# Altium schematic sheet ShematicProva.SchDoc(ShematicProva)
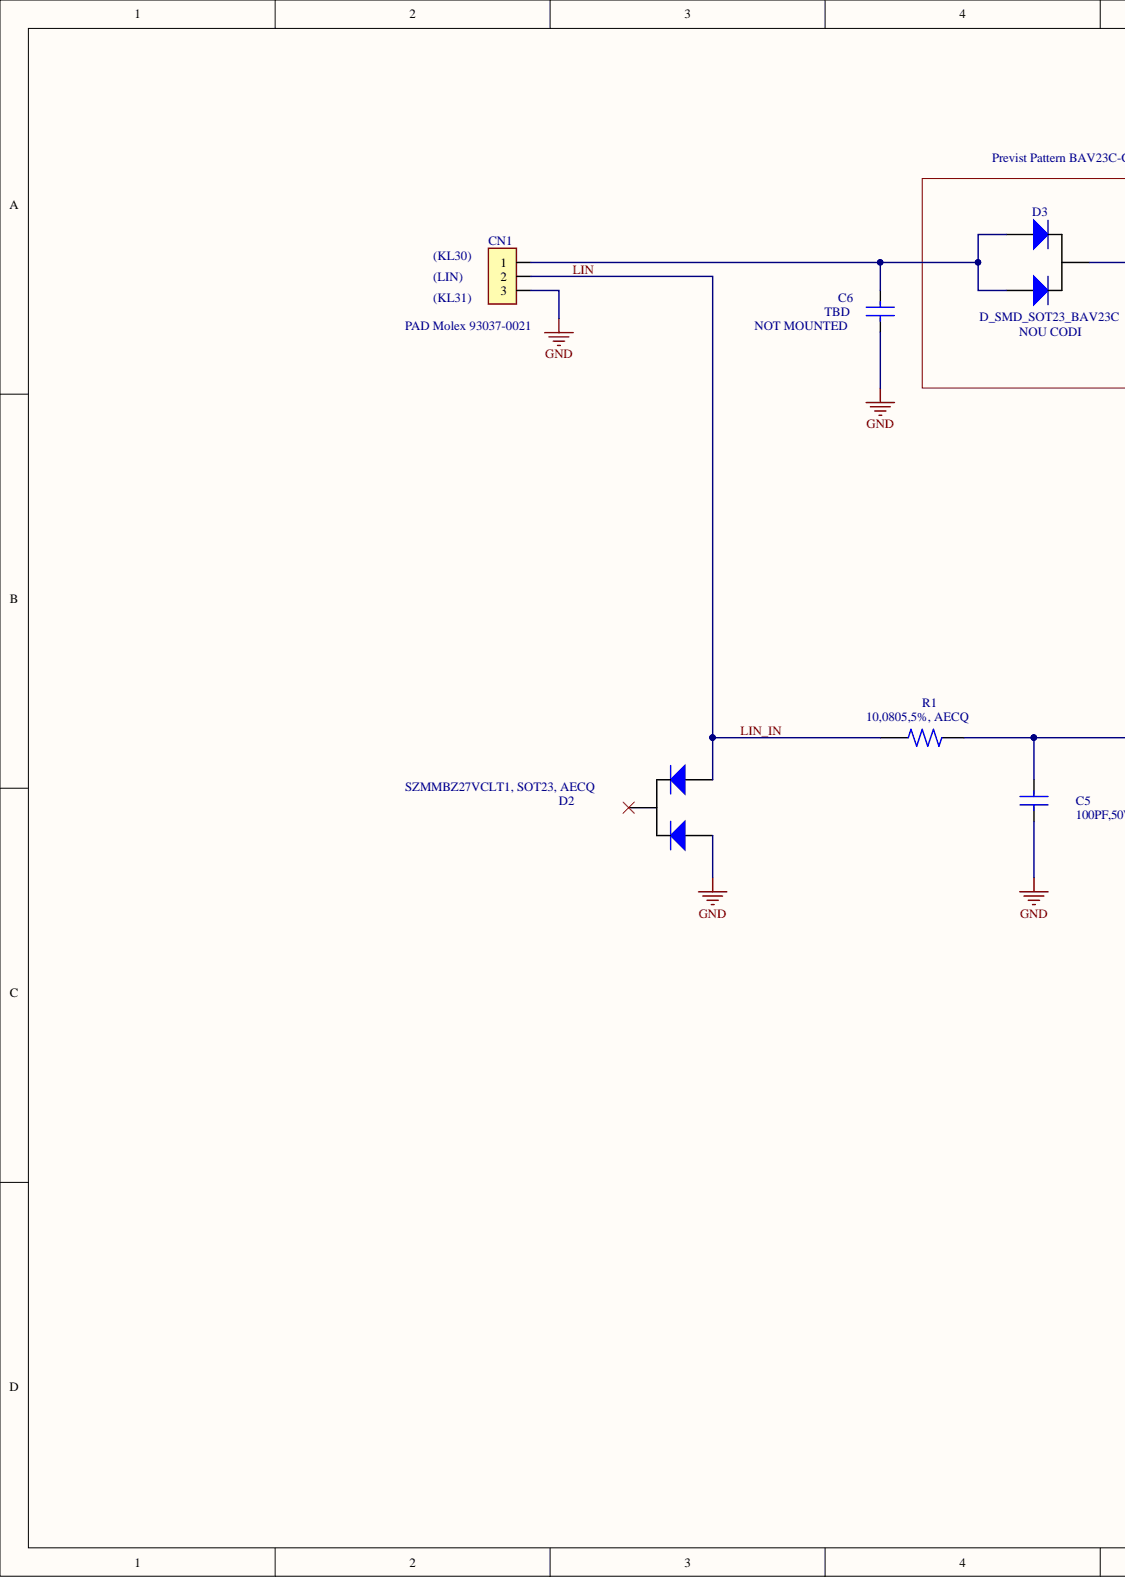
[diagram p0: ShematicProva.SchDoc, left]
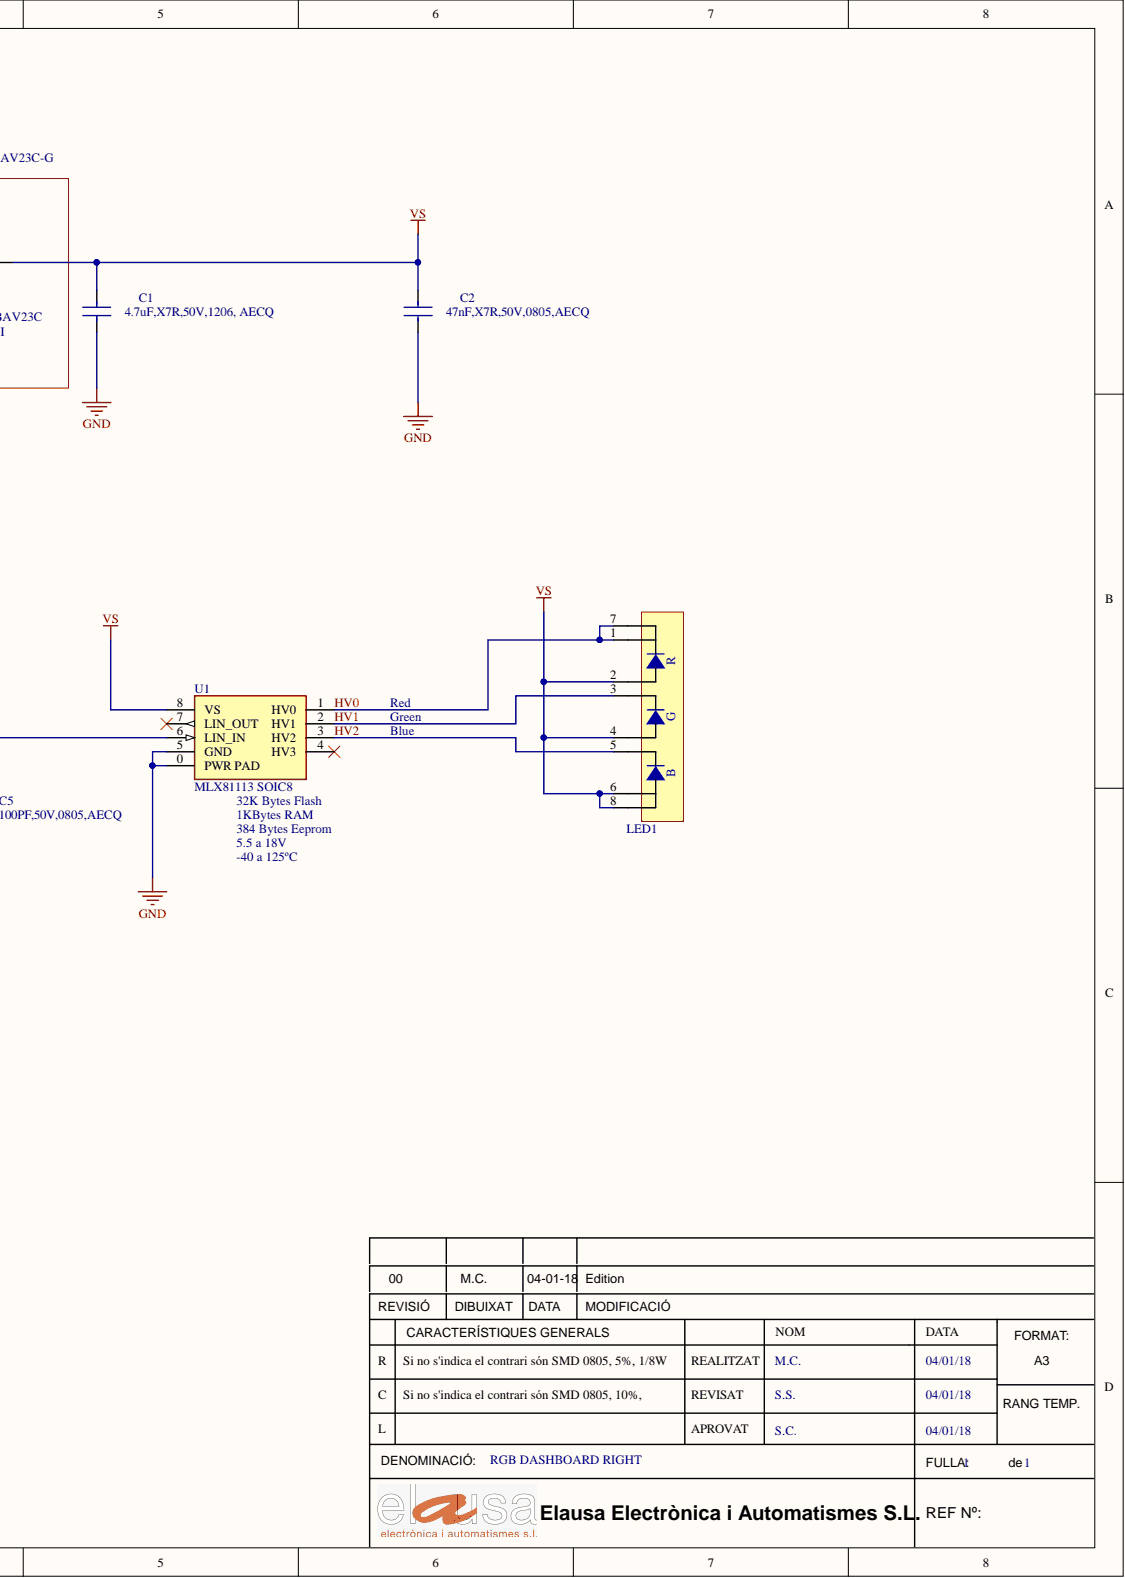
[diagram p1: ShematicProva.SchDoc, right]

## Parts
C1  4.7uF,X7R,50V,1206, AECQ  [C_SMD_1206]
C2  47nF,X7R,50V,0805,AECQ  [C_SMD_0805]
C5  100PF,50V,0805,AECQ  [C_SMD_0805]
C6  TBD  [C_SMD_0805]
CN1  PAD Molex 93037-0021  [93037-0002]
D2  SZMMBZ27VCLT1, SOT23, AECQ  [D_SMD_SOT23_BAT54C]
D3  D_SMD_SOT23_BAV23C  Part Number: NOU CODI  [D_SMD_SOT23_BAV70]
LED1  CRGB1318FSE-TM  [SIDE_LED_LTSA_S008EGBWU]  (value not drawn)
R1  10,0805,5%, AECQ  [R_SMD_0805]
U1  MLX81113 SOIC8  [I_SMD_SO8_POWERPAD]

## Nets
GND: C1.2 C2.2 C5.2 C6.2 CN1.3 D2.AN2 U1.0(PWR_PAD) U1.5(GND)
VS: C1.1 C2.1 D3.CAT LED1.2 LED1.4 LED1.6 LED1.8 U1.8(VS)
HV0: LED1.1 LED1.7 U1.1(HV0)
HV1: LED1.3 U1.2(HV1)
HV2: LED1.5 U1.3(HV2)
LIN: CN1.2 D2.AN1 R1.1
NetC5_1: C5.1 R1.2 U1.6(LIN_IN)
NetC6_1: C6.1 CN1.1 D3.AN1 D3.AN2

Not connected: D2 CAT; U1 4(HV3) 7(LIN_OUT)

## Library data of the parts
C1 = Condensador: 4.7UF/50V 10% X7R 1206 AECQ; Part Number: 761163; Component Kind: Standard; Library Name: Condensadors.SchLib
C2 = Condensador: 47nF,X7R,50V AECQ; Part Number: 763101; Component Kind: Standard; Library Name: Condensadors.SchLib
C5 = Condensador: SMD COND 100PF/50V 10% NPO 0805 AECQ; Part Number: 763184; Component Kind: Standard; Library Name: Condensadors.SchLib
C6 = Condensador: Part Number: NOT MOUNTED; Component Kind: Standard; Library Name: Condensadors.SchLib
CN1 = Connector 3: Part Number: NO MUNTAR; Library Name: Connectors.SchLib; Description: PAD Molex 93037-0021; Component Kind: Standard
D2 = D_SMD_SOT23_BAT54C: MMBZ27VCLT1, SOT23; Part Number: 772061; Library Name: Diodes.SchLib; Component Kind: Standard
D3 = D_SMD_SOT23_BAV70: SMD DIODE BAV23C SOT23 AECQ; Library Name: Diodes.SchLib; Component Kind: Standard
LED1 = SMD LED LTSA-S008EGBWU: Library Name: LED.SchLib; Component Kind: Standard
R1 = R_SMD_0805: SMD RES 10 1/8W 5% 0805 AECQ; Part Number: 703133; Component Kind: Standard; Library Name: Resistencies.SchLib
U1 = MLX81113 SOIC8: Melexis - LIN RGB LED Driver for Automotive Ambient Lighting; Component Kind: Standard; Library Name: Integrats.SchLib
